# Altium schematic sheet Sensor.SchDoc
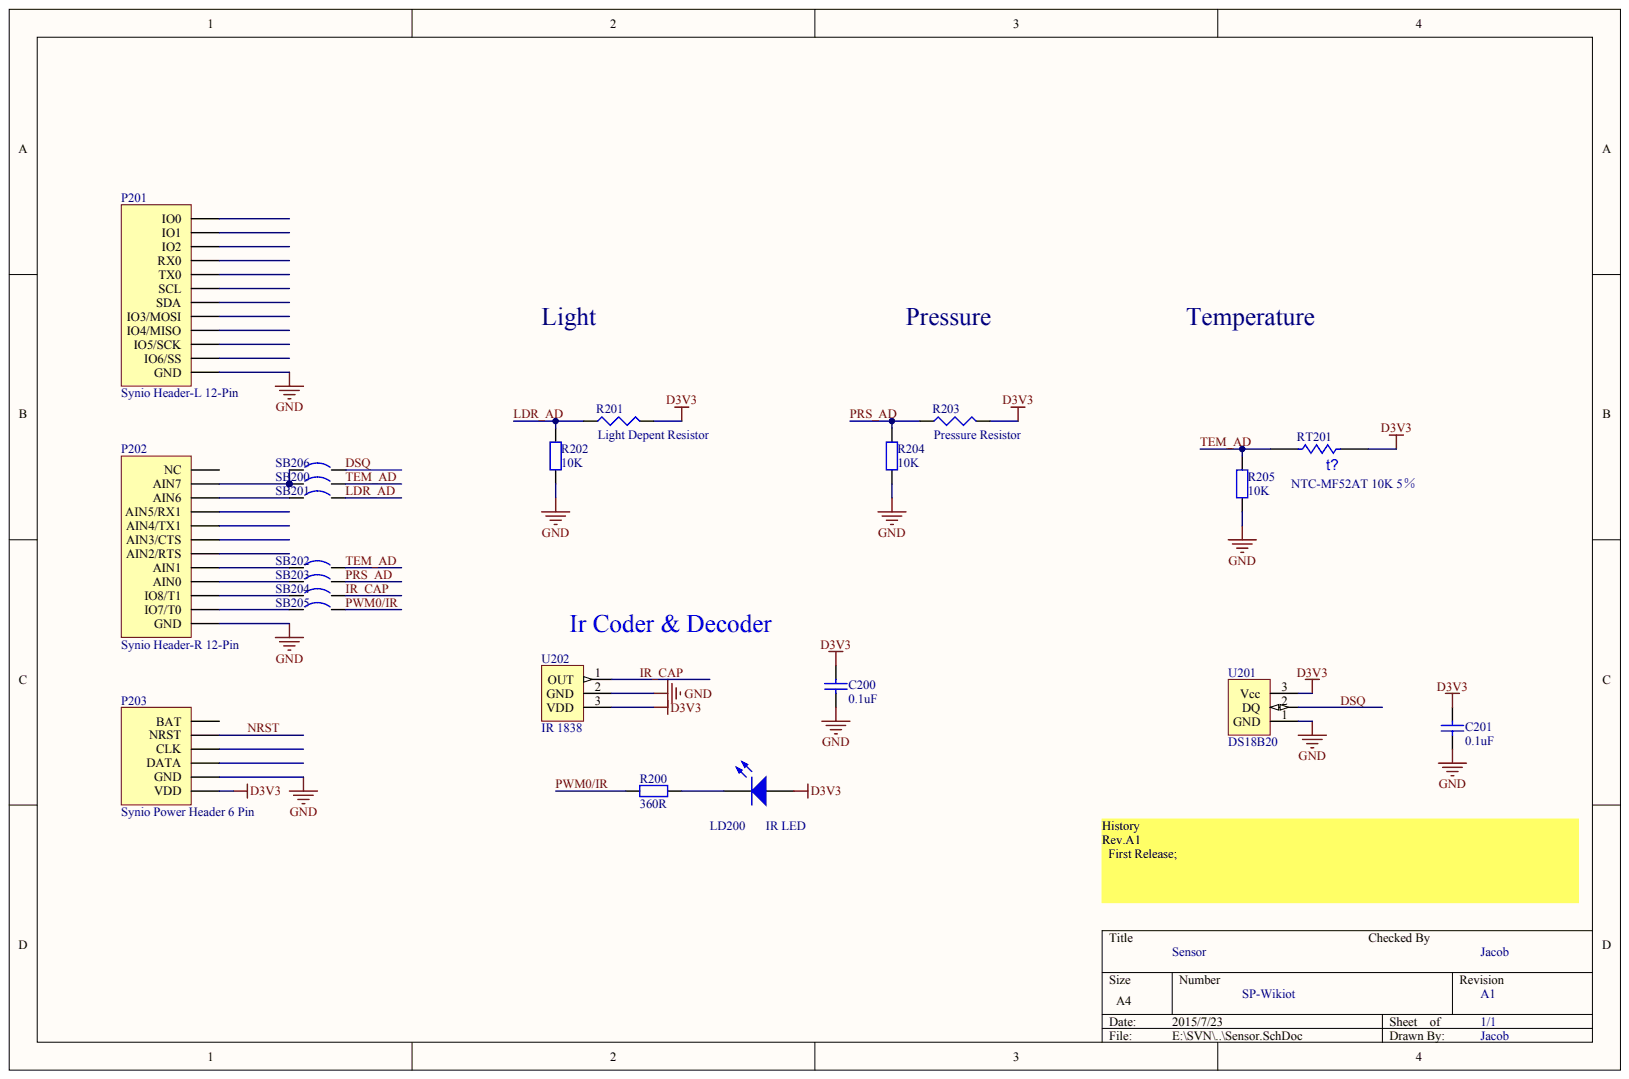
[diagram p0: Sensor.SchDoc]

## Parts
C200  0.1uF
C201  0.1uF
LD200  IR LED
P201  Synio Header-L 12-Pin
P202  Synio Header-R 12-Pin
P203  Synio Power Header 6 Pin
R200  360R
R201  value Light Depent Resistor  (hidden comment: Res3)
R202  10K
R203  value Pressure Resistor  (hidden comment: Res3)
R204  10K
R205  10K
RT201  NTC-MF52AT 10K 5％
SB200  Circuit Breaker  (value not drawn)
SB201  Circuit Breaker  (value not drawn)
SB202  Circuit Breaker  (value not drawn)
SB203  Circuit Breaker  (value not drawn)
SB204  Circuit Breaker  (value not drawn)
SB205  Circuit Breaker  (value not drawn)
SB206  Circuit Breaker  (value not drawn)
U201  DS18B20
U202  IR 1838

## Nets
D3V3: C200.2 C201.2 LD200.1 P203.1(VDD) R201.2 R203.2 RT201.1 U201.3 U202.3
GND: C200.1 C201.1 P201.1(GND) P202.1(GND) P203.2(GND) R202.1 R204.1 R205.1 U201.1 U202.2
DSQ: SB206.2 U201.2
IR_CAP: SB204.2 U202.1
LDR_AD: R201.1 R202.2 SB201.2
NRST: P203.5(NRST)
PRS_AD: R203.1 R204.2 SB203.2
PWM0/IR: R200.2 SB205.2
TEM_AD: R205.2 RT201.2 SB200.2 SB202.2
NetLD200_2: LD200.2 R200.1
NetP201_6: P201.6(SDA)
NetP201_7: P201.7(SCL)
NetP201_11: P201.11(IO1)
NetP201_12: P201.12(IO0)
NetP202_2: P202.2(IO7/T0) SB205.1
NetP202_3: P202.3(IO8/T1) SB204.1
NetP202_4: P202.4(AIN0) SB203.1
NetP202_5: P202.5(AIN1) SB202.1
NetP202_6: P202.6(AIN2/RTS)
NetP202_7: P202.7(AIN3/CTS)
NetP202_10: P202.10(AIN6) SB201.1
NetP202_11: P202.11(AIN7) SB200.1 SB206.1
NetP202_12: P202.12(NC)
NetP203_6: P203.6(BAT)

Not connected: P201 2(IO6/SS) 3(IO5/SCK) 4(IO4/MISO) 5(IO3/MOSI) 8(TX0) 9(RX0) 10(IO2); P202 8(AIN4/TX1) 9(AIN5/RX1); P203 3(DATA) 4(CLK)

## Library data of the parts
SB200-SB206 = (no symbol name): Solder: YES; Published: 24-Mar-1999; Revision: July-2002: Re-released for DXP Platform.; Publisher: Altium Limited
C200 C201 LD200 P201-P203 R200-R205 RT201 U201 U202 = (no symbol name)
  C200 C201: Published: 8-Jun-2000; LatestRevisionDate: 17-Jul-2002; LatestRevisionNote: Re-released for DXP Platform.; PackageReference: RAD-0.3; Value: 0.1uF
  LD200: Published: 8-Jun-2000; LatestRevisionDate: 17-Jul-2002; LatestRevisionNote: Re-released for DXP Platform.; PackageDocument: Feb-2002; PackageReference: 3.2X1.6X1.1
  P201 P202 U201 U202: LatestRevisionDate: 17-Jul-2002; LatestRevisionNote: Re-released for DXP Platform.
  R200: Published: 8-Jun-2000; LatestRevisionDate: 17-Jul-2002; LatestRevisionNote: Re-released for DXP Platform.; PackageReference: AXIAL-0.4; Value: 360R
  R201 R203: Published: 8-Jun-2000; LatestRevisionDate: 29-May-2009; LatestRevisionNote: IPC-7351 Footprint Added.; PackageReference: J1-0603; PackageDescription: Chip Resistor
  R202 R204 R205: Published: 8-Jun-2000; LatestRevisionDate: 17-Jul-2002; LatestRevisionNote: Re-released for DXP Platform.; PackageReference: AXIAL-0.4; Value: 10K
  RT201: Published: 24-Mar-1999; LatestRevisionDate: 29-May-2009; LatestRevisionNote: IPC-7351 Footprint Added.; PackageVersion: Mar-2003; PackageReference: 6-0805; PackageDescription: Chip Resistor 0805 Case Size; Code_IPC: RESC2012
  C200 C201 LD200 P201 P202 R200-R205 RT201 U201 U202: Publisher: Altium Limited
